# Altium schematic sheet NRF24L01_Module_Patch_Antenna.SchDoc
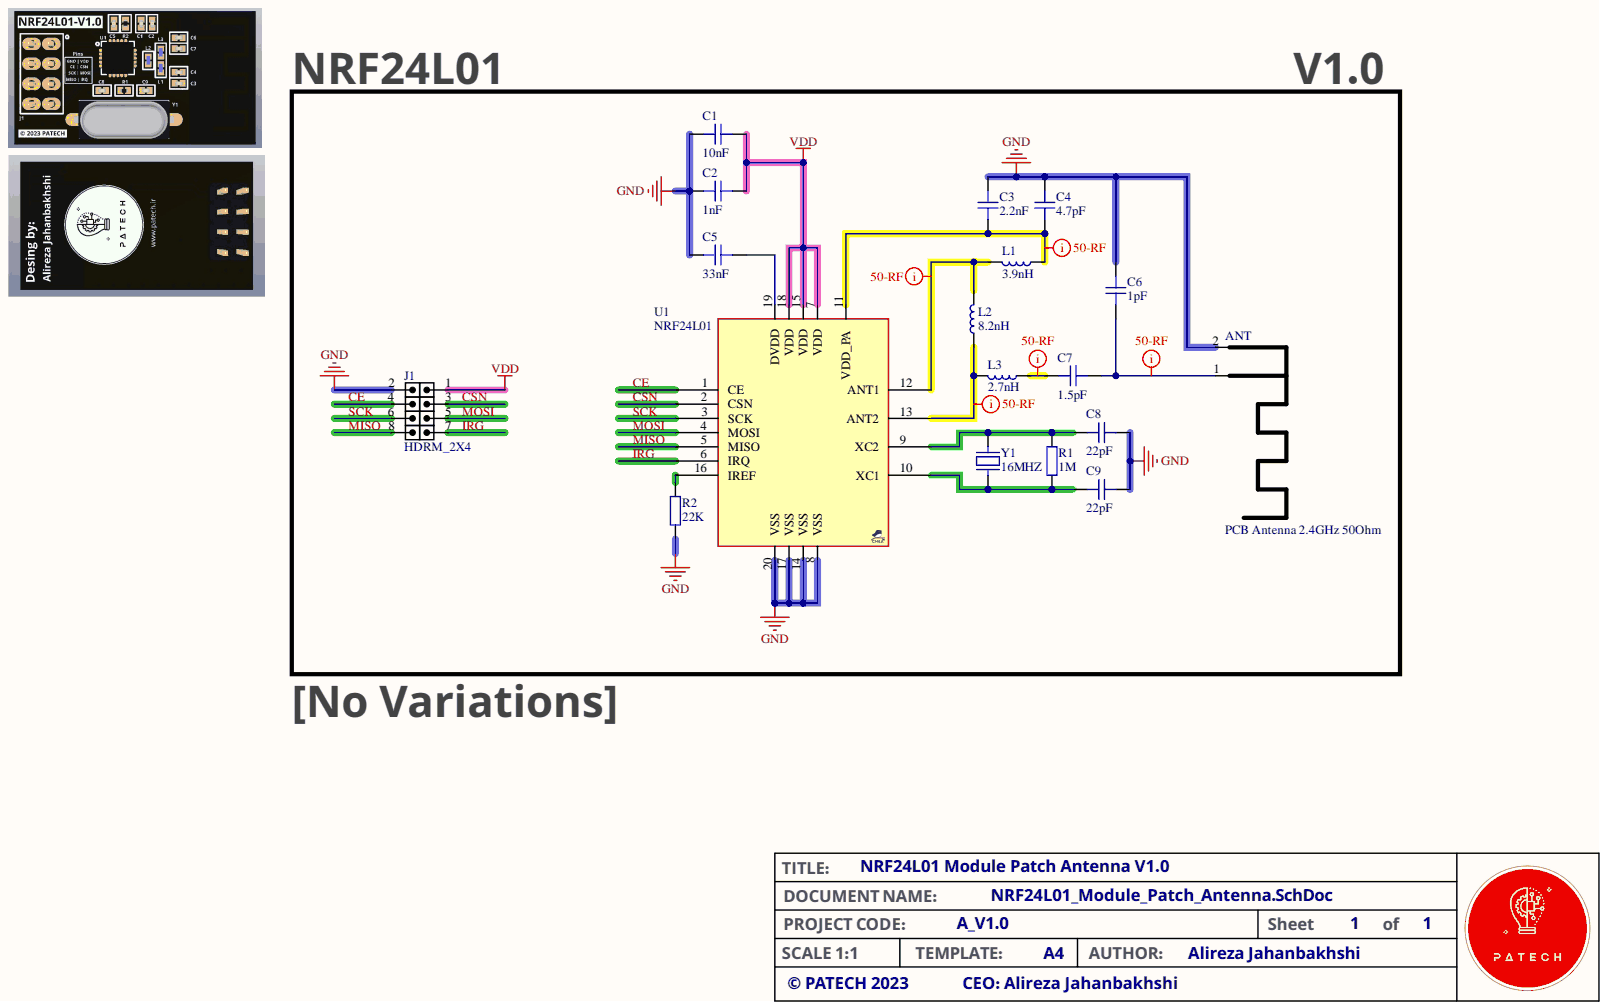
[diagram p0: NRF24L01_Module_Patch_Antenna.SchDoc]

## Parts
ANT  PCB Antenna 2.4GHz 50Ohm  [ANT_IFA_USB_2_4GHZ_50R]  (no BOM)
C1  10nF  [CAP_0402]
C2  1nF  [CAP_0402]
C3  2.2nF  [CAP_0402]
C4  4.7pF  [CAP_0402]
C5  33nF  [CAP_0402]
C6  1pF  [CAP_0402]
C7  1.5pF  [CAP_0402]
C8  22pF  [CAP_0402]
C9  22pF  [CAP_0402]
J1  HDRM_2X4  [HDR_M_2X4]
L1  3.9nH  [IND_0402]
L2  8.2nH  [IND_0402]
L3  2.7nH  [IND_0402]
R1  1M  [RES_0402]
R2  22K  [RES_0402]
U1  NRF24L01  [QFN50_20T]
Y1  16MHZ  [HC-49S SMD]

## Nets
GND: ANT.2 C1.2 C2.2 C3.2 C4.2 C5.2 C6.2 C8.2 C9.2 J1.2 R2.1 U1.8(VSS) U1.14(VSS) U1.17(VSS) U1.20(VSS)
VDD: C1.1 C2.1 J1.1 U1.7(VDD) U1.15(VDD) U1.18(VDD)
CE: J1.4 U1.1(CE)
CSN: J1.3 U1.2(CSN)
IRG: J1.7 U1.6(IRQ)
MISO: J1.8 U1.5(MISO)
MOSI: J1.5 U1.4(MOSI)
SCK: J1.6 U1.3(SCK)
NetANT_1: ANT.1 C6.1 C7.2
NetC3_1: C3.1 C4.1 L1.1 U1.11(VDD_PA)
NetC5_1: C5.1 U1.19(DVDD)
NetC7_1: C7.1 L3.1
NetC8_1: C8.1 R1.2 U1.9(XC2) Y1.2
NetC9_1: C9.1 R1.1 U1.10(XC1) Y1.1
NetL1_2: L1.2 L2.2 U1.12(ANT1)
NetL2_1: L2.1 L3.2 U1.13(ANT2)
NetR2_2: R2.2 U1.16(IREF)

## Library data of the parts
ANT = ANT_IFA_USB_2_4GHZ_50R: Inverted F 2.4GHz ISM PCB Antenna 50 Ohm; ComponentLink1Description: Datasheet; ComponentLink1URL: https://www.ti.com/lit/an/swra117d/swra117d.pdf; Library Name: Schematic-Lib-PhilsLab.SchLib
C1-C9 = CAP_0402_10V: Capacitor SMD 0402 10V; Component Kind: Standard; Library Name: Schematic-Lib-PhilsLab.SchLib
J1 = HDR_M_2X4: CONN, HEADER, Male, pitch: 2.54mm, 8POS; MANF_P#: MANF_PART#; Component Kind: Standard; Library Name: Conn Header.IntLib; MANF: MANF; VALUE: HDRM_2X4
L1-L3 = IND_0402: Inductor SMD 0402; Component Kind: Standard; Library Name: Schematic-Lib-PhilsLab.SchLib
R1 R2 = RES_0402: Resistor SMD 0402; Component Kind: Standard; Library Name: Schematic-Lib-PhilsLab.SchLib
U1 = NRF24L01_QFN20: 2.4GHz Transceiver; MANF: NORDIC; PARAM2: 1.9~3.6VCC; Component Kind: Standard; Library Name: module_rf_wireless.SchLib; MANF_P#: NRF24L01; VALUE: NRF24L01
Y1 = Crystal HC-49S SMD: SMT Crystal; Component Kind: Standard; Library Name: Xtal.IntLib; VALUE: 16MHZ
